# Altium schematic sheet CLOCK.SchDoc
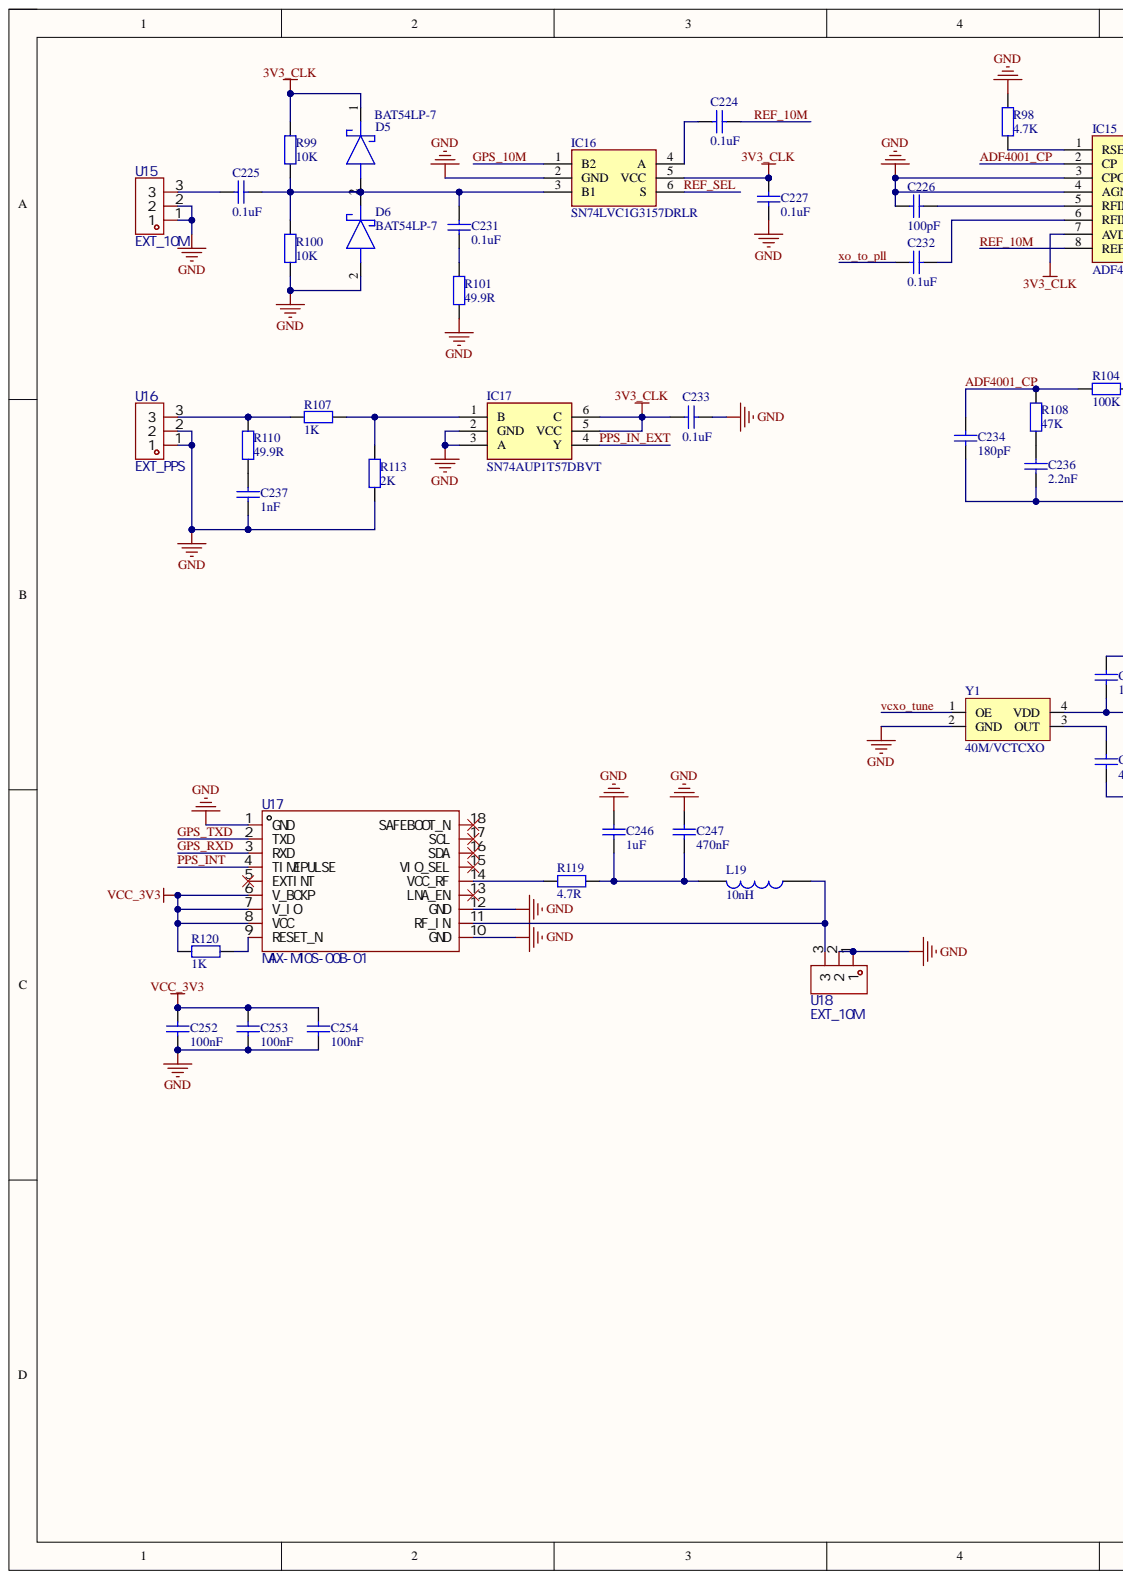
[diagram p0: CLOCK.SchDoc, left]
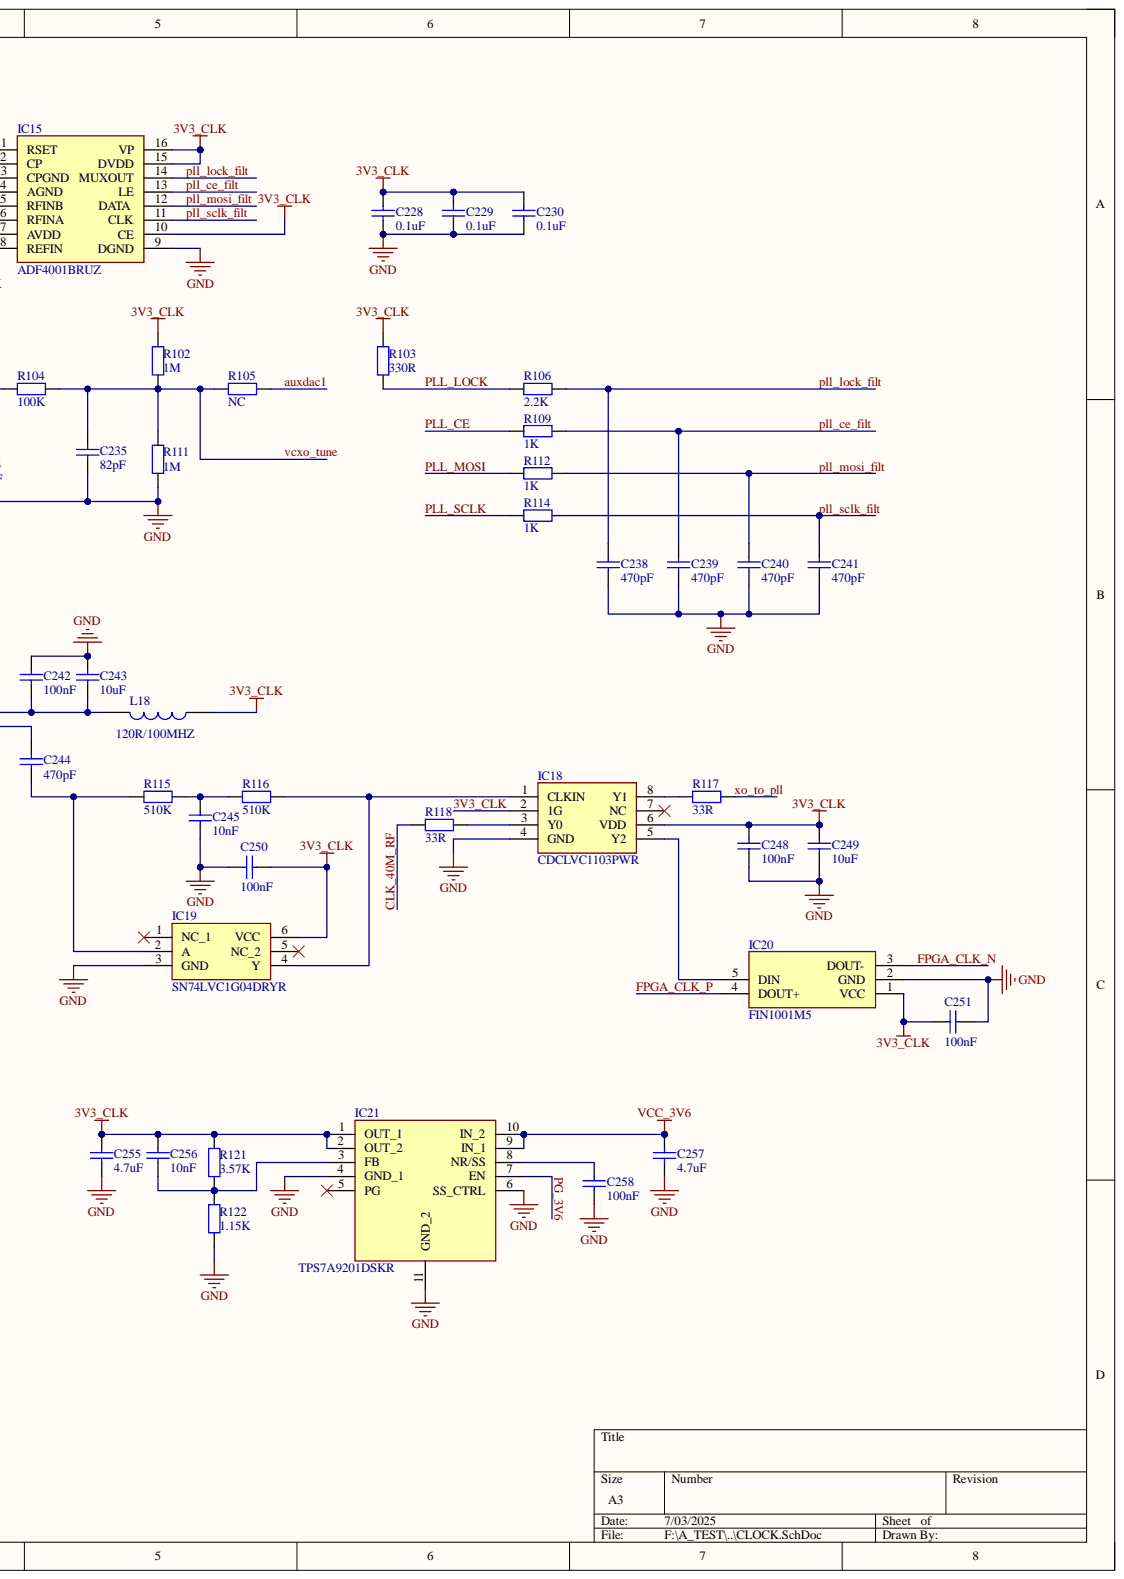
[diagram p1: CLOCK.SchDoc, right]

## Parts
C224  0.1uF  [C 0402_L]
C225  0.1uF  [C 0402_L]
C226  100pF  [C 0402_L]
C227  0.1uF  [C 0402_L]
C228  0.1uF  [C 0402_L]
C229  0.1uF  [C 0402_L]
C230  0.1uF  [C 0402_L]
C231  0.1uF  [C 0402_L]
C232  0.1uF  [C 0402_L]
C233  0.1uF  [C 0402_L]
C234  180pF  [C 0402_L]
C235  82pF  [C 0402_L]
C236  2.2nF  [C 0402_L]
C237  1nF  [C 0402_L]
C238  470pF  [C 0402_L]
C239  470pF  [C 0402_L]
C240  470pF  [C 0402_L]
C241  470pF  [C 0402_L]
C242  100nF  [C 0402_L]
C243  10uF  [C 0603_L]
C244  470pF  [C 0402_L]
C245  10nF  [C 0402_L]
C246  1uF  [C 0402_L]
C247  470nF  [C 0402_L]
C248  100nF  [C 0402_L]
C249  10uF  [C 0603_L]
C250  100nF  [C 0402_L]
C251  100nF  [C 0402_L]
C252  100nF  [C 0402_L]
C253  100nF  [C 0402_L]
C254  100nF  [C 0402_L]
C255  4.7uF  [C 0402_L]
C256  10nF  [C 0402_L]
C257  4.7uF  [C 0402_L]
C258  100nF  [C 0402_L]
D5  BAT54LP-7  [DESD3V3S1BL7B]
D6  BAT54LP-7  [DESD3V3S1BL7B]
IC15  ADF4001BRUZ  [SOP65P640X120-16N]
IC16  SN74LVC1G3157DRLR  [SOTFL50P160X60-6N]
IC17  SN74AUP1T57DBVT  [SOT95P280X145-6N]
IC18  CDCLVC1103PWR  [SOP65P640X120-8N]
IC19  SN74LVC1G04DRYR  [TPS3420DDRYR]
IC20  FIN1001M5  [SOT95P280X145-5N]
IC21  TPS7A9201DSKR  [SON50P250X250X80-11N-D]
L18  120R/100MHZ  [L 0603]
L19  10nH  [L 0402]
R98  4.7K  [R 0402_L]
R99  10K  [R 0402_L]
R100  10K  [R 0402_L]
R101  49.9R  [R 0402_L]
R102  1M  [R 0402_L]
R103  330R  [R 0402_L]
R104  100K  [R 0402_L]
R105  NC  [R 0402_L]
R106  2.2K  [R 0402_L]
R107  1K  [R 0402_L]
R108  47K  [R 0402_L]
R109  1K  [R 0402_L]
R110  49.9R  [R 0402_L]
R111  1M  [R 0402_L]
R112  1K  [R 0402_L]
R113  2K  [R 0402_L]
R114  1K  [R 0402_L]
R115  510K  [R 0402_L]
R116  510K  [R 0402_L]
R117  33R  [R 0402_L]
R118  33R  [R 0402_L]
R119  4.7R  [R 0402_L]
R120  1K  [R 0402_L]
R121  3.57K  [R 0402_L]
R122  1.15K  [R 0402_L]
U15  EXT_10M  [SMA-SMD_73415-0963]
U16  EXT_PPS  [SMA-SMD_73415-0963]
U17  MAX-M10S-00B-01  [GPS-SMD_18P-L10.1-W9.7-P1.10-BR]
U18  EXT_10M  [SMA-SMD_73415-0963]
Y1  40M/VCTCXO  [OSC 3225-4P]

## Nets
GND: C226.2 C227.1 C228.2 C229.2 C230.2 C233.2 C234.1 C235.2 C236.1 C237.1 C238.2 C239.2 C240.2 C241.2 C242.2 C243.2 C245.2 C246.2 C247.2 C248.1 C249.1 C250.1 C251.1 C252.1 C253.1 C254.1 C255.1 C257.1 C258.1 D6.2 IC15.3(CPGND) IC15.4(AGND) IC15.9(DGND) IC16.2(GND) IC17.2(GND) IC17.3(A) IC18.4(GND) IC19.3(GND) IC20.2(GND) IC21.4(GND_1) IC21.6(SS_CTRL) IC21.11(GND_2) R98.1 R100.1 R101.1 R111.2 R113.2 R122.1 U15.1 U15.2 U16.1 U16.2 U17.1(GND) U17.10(GND) U17.12(GND) U18.1 U18.2 Y1.2(GND)
VCC_3V3: C252.2 C253.2 C254.2 R120.2 U17.6(V_BCKP) U17.7(V_IO) U17.8(VCC)
VCC_3V6: C257.2 IC21.9(IN_1) IC21.10(IN_2)
3V3_CLK: C227.2 C228.1 C229.1 C230.1 C233.1 C248.2 C249.2 C250.2 C251.2 C255.2 C256.2 D5.1 IC15.7(AVDD) IC15.10(CE) IC15.15(DVDD) IC15.16(VP) IC16.5(VCC) IC17.5(VCC) IC17.6(C) IC18.2(1G) IC18.6(VDD) IC19.6(VCC) IC20.1(VCC) IC21.1(OUT_1) IC21.2(OUT_2) L18.1 R99.2 R102.1 R103.1 R121.2
ADF4001_CP: C234.2 IC15.2(CP) R104.1 R108.1
auxdac1: R105.2
CLK_40M_RF: R118.2
FPGA_CLK_N: IC20.3(DOUT-)
FPGA_CLK_P: IC20.4(DOUT+)
GPS_10M: IC16.1(B2)
GPS_RXD: U17.3(RXD)
GPS_TXD: U17.2(TXD)
PG_3V6: IC21.7(EN)
PLL_CE: R109.2
pll_ce_filt: C239.1 IC15.13(LE) R109.1
PLL_LOCK: R103.2 R106.2
pll_lock_filt: C238.1 IC15.14(MUXOUT) R106.1
PLL_MOSI: R112.2
pll_mosi_filt: C240.1 IC15.12(DATA) R112.1
PLL_SCLK: R114.2
pll_sclk_filt: C241.1 IC15.11(CLK) R114.1
PPS_IN_EXT: IC17.4(Y)
PPS_INT: U17.4(TIMEPULSE)
REF_10M: C224.1 IC15.8(REFIN)
REF_SEL: IC16.6(S)
vcxo_tune: C235.1 R102.2 R104.2 R105.1 R111.1 Y1.1(OE)
xo_to_pll: C232.2 R117.2
NetC224_2: C224.2 IC16.4(A)
NetC225_1: C225.1 U15.3
NetC225_2: C225.2 C231.1 D5.2 D6.1 IC16.3(B1) R99.1 R100.2
NetC226_1: C226.1 IC15.5(RFINB)
NetC231_2: C231.2 R101.2
NetC232_1: C232.1 IC15.6(RFINA)
NetC236_2: C236.2 R108.2
NetC237_2: C237.2 R110.1
NetC242_1: C242.1 C243.1 L18.2 Y1.4(VDD)
NetC244_1: C244.1 Y1.3(OUT)
NetC244_2: C244.2 IC19.2(A) R115.1
NetC245_1: C245.1 R115.2 R116.1
NetC246_1: C246.1 C247.1 L19.2 R119.2
NetC256_1: C256.1 IC21.3(FB) R121.1 R122.2
NetC258_2: C258.2 IC21.8(NR/SS)
NetIC15_1: IC15.1(RSET) R98.2
NetIC17_1: IC17.1(B) R107.1 R113.1
NetIC18_1: IC18.1(CLKIN) IC19.4(Y) R116.2
NetIC18_3: IC18.3(Y0) R118.1
NetIC18_5: IC18.5(Y2) IC20.5(DIN)
NetIC18_8: IC18.8(Y1) R117.1
NetL19_1: L19.1 U17.11(RF_IN) U18.3
NetR107_2: R107.2 R110.2 U16.3
NetR119_1: R119.1 U17.14(VCC_RF)
NetR120_1: R120.1 U17.9(RESET_N)

Not connected: IC18 7(NC); IC19 1(NC_1) 5(NC_2); IC21 5(PG); U17 5(EXTINT) 13(LNA_EN) 15(VIO_SEL) 16(SDA) 17(SCL) 18(SAFEBOOT_N)

## Library data of the parts
C224-C258 = Cap: 贴片电容; Component Kind: Standard; Library Name: A_Schlib.SchLib
  C224 C225 C227-C233: value: 0.1uF
  C226: value: 100pF
  C234: value: 180pF
  C235: value: 82pF
  C236: value: 2.2nF
  C237: value: 1nF
  C238-C241 C244: value: 470pF
  C242 C248 C250-C254 C258: value: 100nF
  C243 C249: value: 10uF
  C245 C256: value: 10nF
  C246: value: 1uF
  C247: value: 470nF
  C255 C257: value: 4.7uF
D5 D6 = BAT54LP-7: Schottky Diode; Manufacturer_Name: Diodes Incorporated; Arrow Part Number: BAT54LP-7; Arrow Price/Stock: https://www.arrow.com/en/products/bat54lp-7/diodes-incorporated?utm_currency=USD&region=nac; Component Kind: Standard; Datasheet Link: https://www.diodes.com//assets/Datasheets/BAT54LP.pdf; Library Name: SamacSys.SchLib; Manufacturer_Part_Number: BAT54LP-7; Mouser Part Number: 621-BAT54LP-7; Mouser Price/Stock: https://www.mouser.co.uk/ProductDetail/Diodes-Incorporated/BAT54LP-7?qs=rGAXPo9uwV29av2jO%2FVbTg%3D%3D
IC15 = ADF4001BRUZ: Integrated Circuit; Manufacturer_Name: Analog Devices; Arrow Part Number: ADF4001BRUZ; Arrow Price/Stock: https://www.arrow.com/en/products/adf4001bruz/maxim-integrated?region=nac; Component Kind: Standard; Datasheet Link: http://www.analog.com/static/imported-files/data_sheets/ADF4001.pdf; Height: 1.2mm; Library Name: SamacSys.SchLib; Manufacturer_Part_Number: ADF4001BRUZ; Mouser Part Number: 584-ADF4001BRUZ; Mouser Price/Stock: https://www.mouser.co.uk/ProductDetail/Analog-Devices/ADF4001BRUZ?qs=BpaRKvA4VqFDGFvzhGXRyA%3D%3D
IC16 = SN74LVC1G3157DRLR: Integrated Circuit; Manufacturer_Name: Texas Instruments; Arrow Part Number: SN74LVC1G3157DRLR; Arrow Price/Stock: https://www.arrow.com/en/products/sn74lvc1g3157drlr/texas-instruments?utm_currency=USD&region=nac; Component Kind: Standard; Datasheet Link: http://www.ti.com/lit/gpn/sn74lvc1g3157; Height: 0.6mm; Library Name: SamacSys.SchLib; Manufacturer_Part_Number: SN74LVC1G3157DRLR; Mouser Part Number: 595-SN74LVC1G3157DRL; Mouser Price/Stock: https://www.mouser.co.uk/ProductDetail/Texas-Instruments/SN74LVC1G3157DRLR?qs=PVVDbbWpW3LmfSj83Os0NA%3D%3D
IC17 = SN74AUP1T57DBVT: Integrated Circuit; Manufacturer_Name: Texas Instruments; Arrow Part Number: SN74AUP1T57DBVT; Arrow Price/Stock: https://www.arrow.com/en/products/sn74aup1t57dbvt/texas-instruments?region=nac; Component Kind: Standard; Datasheet Link: https://www.ti.com/lit/ds/sces611g/sces611g.pdf?HQS=dis-mous-null-mousermode-dsf-pf-null-wwe&ts=1630648282615&ref_url=https%253A%252F%252Feu.mouser.com%252F; Height: 1.45mm; Library Name: SamacSys.SchLib; Manufacturer_Part_Number: SN74AUP1T57DBVT; Mouser Part Number: 595-SN74AUP1T57DBVT; Mouser Price/Stock: https://www.mouser.co.uk/ProductDetail/Texas-Instruments/SN74AUP1T57DBVT?qs=gb35HGp1gQLfojKE2F8ChQ%3D%3D
IC18 = CDCLVC1103PWR: Integrated Circuit; Manufacturer_Name: Texas Instruments; Arrow Part Number: CDCLVC1103PWR; Arrow Price/Stock: https://www.arrow.com/en/products/cdclvc1103pwr/texas-instruments?region=nac; Component Kind: Standard; Datasheet Link: http://www.ti.com/lit/gpn/cdclvc1103; Height: 1.2mm; Library Name: SamacSys.SchLib; Manufacturer_Part_Number: CDCLVC1103PWR; Mouser Part Number: 595-CDCLVC1103PWR; Mouser Price/Stock: https://www.mouser.co.uk/ProductDetail/Texas-Instruments/CDCLVC1103PWR?qs=CLImetaeaXV5gv3gJV8gTw%3D%3D
IC19 = SN74LVC1G04DRYR: Integrated Circuit; Manufacturer_Name: Texas Instruments; Arrow Part Number: SN74LVC1G04DRYR; Arrow Price/Stock: https://www.arrow.com/en/products/sn74lvc1g04dryr/texas-instruments?region=nac; Component Kind: Standard; Datasheet Link: http://www.ti.com/lit/gpn/sn74lvc1g04; Height: 0mm; Library Name: SamacSys.SchLib; Manufacturer_Part_Number: SN74LVC1G04DRYR; Mouser Part Number: 595-SN74LVC1G04DRYR; Mouser Price/Stock: https://www.mouser.co.uk/ProductDetail/Texas-Instruments/SN74LVC1G04DRYR?qs=7kv20MEVUlgyCI6MY8upNw%3D%3D
IC20 = FIN1001M5: Integrated Circuit; Manufacturer_Name: onsemi; Component Kind: Standard; Datasheet Link: https://www.mouser.sg/ProductDetail/onsemi-Fairchild/FIN1001M5?qs=JO9JUh%252Bewuk3rbYf4QPHFg%3D%3D&srsltid=AfmBOopaihQI_8RlGgHcEFwJNeD4olrvv-vCIZuf58o4MAQGgeG-LMuM; Height: 1.45mm; Library Name: SamacSys.SchLib; Manufacturer_Part_Number: FIN1001M5
IC21 = TPS7A9101DSKR: Integrated Circuit; Manufacturer_Name: Texas Instruments; Arrow Part Number: TPS7A9101DSKR; Arrow Price/Stock: https://www.arrow.com/en/products/tps7a9101dskr/texas-instruments?region=nac; Component Kind: Standard; Datasheet Link: http://www.ti.com/lit/ds/symlink/tps7a91.pdf; Height: 0.8mm; Manufacturer_Part_Number: TPS7A9101DSKR; Mouser Part Number: 595-TPS7A9101DSKR; Mouser Price/Stock: https://www.mouser.co.uk/ProductDetail/Texas-Instruments/TPS7A9101DSKR?qs=34RfhUjJmKdov7HQNWp7rg%3D%3D
L18 L19 = 电感: 包含功率; Component Kind: Standard; Library Name: A_Schlib.SchLib
R98-R122 = Res: 通用; Component Kind: Standard; Library Name: A_Schlib.SchLib
  R98: value: 4.7K
  R99 R100: value: 10K
  R101 R110: value: 49.9R
  R102 R111: value: 1M
  R103: value: 330R
  R104: value: 100K
  R105: value: NC
  R106: value: 2.2K
  R107 R109 R112 R114 R120: value: 1K
  R108: value: 47K
  R113: value: 2K
  R115 R116: value: 510K
  R117 R118: value: 33R
  R119: value: 4.7R
  R121: value: 3.57K
  R122: value: 1.15K
U15 U16 U18 = HJ-MMCX008: Manufacturer: 皇捷; Add into BOM: yes; RF Series: MMCX; Component Kind: Standard; Convert to PCB: yes; Datasheet: https://atta.szlcsc.com/upload/public/pdf/source/20230417/A13D8D93BC4451C7904403BCE88E907A.pdf; Device: HJ-MMCX008; JLCPCB Part Class: 扩展库; LCSC Part Name: MMCX; Library Name: A_Schlib.SchLib; Manufacturer Part: HJ-MMCX008; PCB3D: SMA-SMD_73415-0963; Supplier: LCSC; Supplier Footprint: -; Supplier Part: C5451762; Symbol: HJ-MMCX008
U17 = MAX-M10S-00B-01: Manufacturer: U-BLOX; Add into BOM: yes; Component Kind: Standard; Convert to PCB: yes; Datasheet: https://atta.szlcsc.com/upload/public/pdf/source/20240704/349AEC83DB86EEBDA8CD360EE415F36C.pdf; Device: MAX-M10S-00B-01; JLCPCB Part Class: 扩展库; LCSC Part Name: MAX-M10S-00B-01; Library Name: A_Schlib.SchLib; Manufacturer Part: MAX-M10S-00B-01; PCB3D: GPS-SMD_18P-L10.1-W9.7-P1.10-BR; Supplier: LCSC; Supplier Footprint: -; Supplier Part: C24834155; Symbol: MAX-M10S-00B-01
Y1 = XATLS-4P: 4脚贴片有源晶振; Component Kind: Standard; Library Name: A_Schlib.SchLib; Value: 40M/VCTCXO; 封装库来自淘宝卖家 ID：黄晶宝石: https://shop463601444.taobao.com
